# Altium schematic sheet MonRaF_Pi.SchDoc
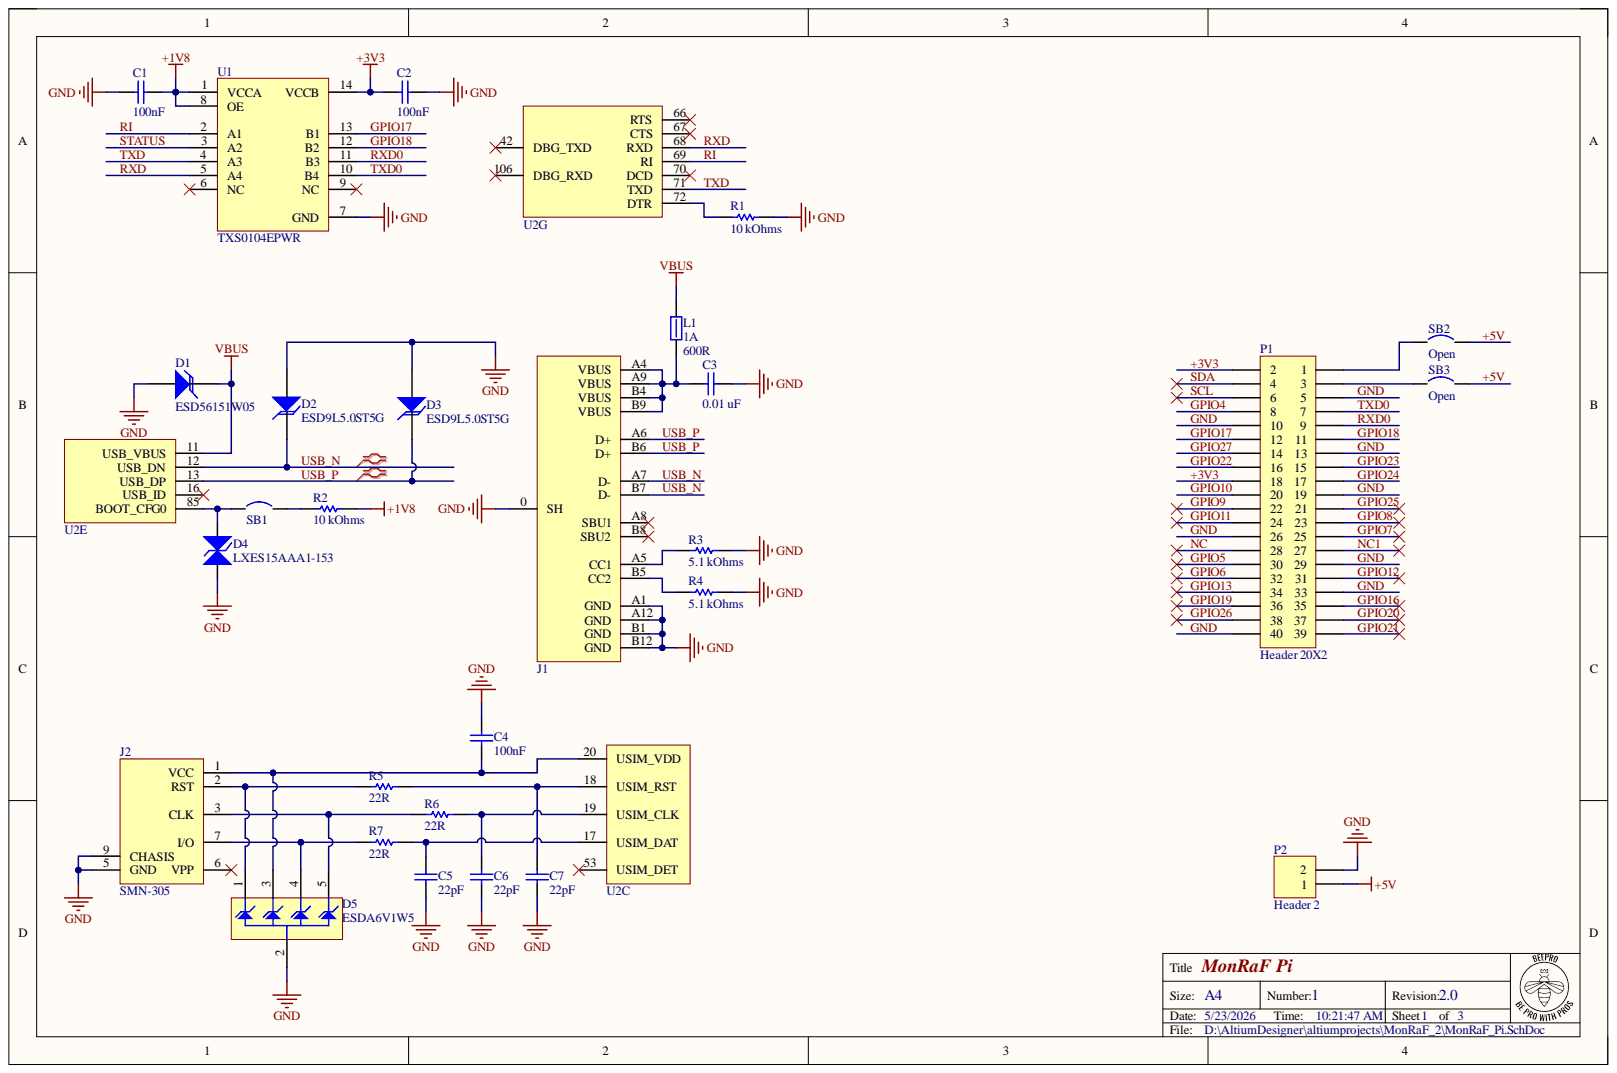
[diagram p0: MonRaF_Pi.SchDoc]

## Parts
C1  Capacitance: 100nF  [0402N]  (hidden comment: 04026D104KAT2A)
C2  Capacitance: 100nF  [0402N]  (hidden comment: 04026D104KAT2A)
C3  Capacitance: 0.01 uF  [0402N]  (hidden comment: CGA2B3X7S2A103K050BB)
C4  Capacitance: 100nF  [0402N]  (hidden comment: 04026D104KAT2A)
C5  Capacitance: 22pF  [0402N]  (hidden comment: 885012005009)
C6  Capacitance: 22pF  [0402N]  (hidden comment: 885012005009)
C7  Capacitance: 22pF  [0402N]  (hidden comment: 885012005009)
D1  ESD56151W05  [SOD-323]
D2  ESD9L5.0ST5G  [SOD923-2N]
D3  ESD9L5.0ST5G  [SOD923-2N]
D4  LXES15AAA1-153  [DFN90P100X45-2N]
D5  ESDA6V1W5  [SOT323-5N]
J1  USB-TYPE-C-018  [USB_C]  (value not drawn)
J2  SMN-305  [NanoSIM_1]
L1  Impedance: 600R  Max Current Rating: 1A  [0603N]  (hidden comment: MPZ1608S601ATD25)
P1  Header 20X2  [HDR2X20]
P2  Header 2  [HDR1X2]
R1  Resistance: 10 kOhms  [0402N]  (hidden comment: CRCW040210K0FKED)
R2  Resistance: 10 kOhms  [0402N]  (hidden comment: CRCW040210K0FKED)
R3  Resistance: 5.1 kOhms  [0402N]  (hidden comment: CRCW04025K10JNED)
R4  Resistance: 5.1 kOhms  [0402N]  (hidden comment: CRCW04025K10JNED)
R5  value 22R  [0402N]  (hidden comment: ERJ-2RKF22R0X)
R6  value 22R  [0402N]  (hidden comment: ERJ-2RKF22R0X)
R7  value 22R  [0402N]  (hidden comment: ERJ-2RKF22R0X)
SB1  Open  [SB_0603]  (value not drawn)
SB2  Open  [SB_0603]
SB3  Open  [SB_0603]
U1  TXS0104EPWR  [TSSOP-14N]
U2  SIM7600G-H  [LCC+LGA-119N]  (value not drawn)  (parts C,E,G)

## Nets
+1V8: C1.1 R2.2 U1.1(VCCA) U1.8(OE)
VBUS: D1.1 L1.2 U2.11(USB_VBUS)
+3V3: C2.2 P1.2 P1.18 U1.14(VCCB)
+5V: P2.1 SB2.2 SB3.2
GND: C1.2 C2.1 C3.2 C4.2 C5.1 C6.1 C7.1 D1.2 D2.2 D3.2 D4.2 D5.2 J1.0(SH) J1.A1(GND) J1.A12(GND) J1.B1(GND) J1.B12(GND) J2.5(GND) J2.9(CHASIS) P1.5 P1.10 P1.13 P1.19 P1.26 P1.29 P1.33 P1.40 P2.2 R1.2 R3.2 R4.2 U1.7(GND)
GPIO4: P1.8
GPIO5: P1.30
GPIO6: P1.32
GPIO7: P1.25
GPIO8: P1.23
GPIO9: P1.22
GPIO10: P1.20
GPIO11: P1.24
GPIO12: P1.31
GPIO13: P1.34
GPIO16: P1.35
GPIO17: P1.12 U1.13(B1)
GPIO18: P1.11 U1.12(B2)
GPIO19: P1.36
GPIO20: P1.37
GPIO21: P1.39
GPIO22: P1.16
GPIO23: P1.15
GPIO24: P1.17
GPIO25: P1.21
GPIO26: P1.38
GPIO27: P1.14
NC: P1.28
NC1: P1.27
RI: U1.2(A1) U2.69(RI)
RXD: U1.5(A4) U2.68(RXD)
RXD0: P1.9 U1.11(B3)
SCL: P1.6
SDA: P1.4
STATUS: U1.3(A2)
TXD: U1.4(A3) U2.71(TXD)
TXD0: P1.7 U1.10(B4)
USB_N: D2.1 J1.A7(D-) J1.B7(D-) U2.12(USB_DN)
USB_P: D3.1 J1.A6(D+) J1.B6(D+) U2.13(USB_DP)
NetC3_1: C3.1 J1.A4(VBUS) J1.A9(VBUS) J1.B4(VBUS) J1.B9(VBUS) L1.1
NetC4_1: C4.1 D5.3 J2.1(VCC) U2.20(USIM_VDD)
NetC5_2: C5.2 R7.2 U2.17(USIM_DAT)
NetC6_2: C6.2 R6.2 U2.19(USIM_CLK)
NetC7_2: C7.2 R5.2 U2.18(USIM_RST)
NetD4_1: D4.1 SB1.1 U2.85(BOOT_CFG0)
NetD5_1: D5.1 J2.2(RST) R5.1
NetD5_4: D5.4 J2.7(I/O) R7.1
NetD5_5: D5.5 J2.3(CLK) R6.1
NetJ1_A5: J1.A5(CC1) R3.1
NetJ1_B5: J1.B5(CC2) R4.1
NetP1_1: P1.1 SB2.1
NetP1_3: P1.3 SB3.1
NetR1_1: R1.1 U2.72(DTR)
NetR2_1: R2.1 SB1.2

Not connected: J1 A8(SBU1) B8(SBU2); J2 6(VPP); U1 6(NC) 9(NC); U2 16(USB_ID) 42(DBG_TXD) 53(USIM_DET) 66(RTS) 67(CTS) 70(DCD) 106(DBG_RXD)

## Library data of the parts
C1 C2 C4 = 04026D104KAT2A: AVX   04026D104KAT2A   Multilayer Ceramic Capacitor, 0.1 F,  10%, X5R, 6.3 V, 0402 [1005 Metric]; Manufacturer: Kyocera AVX; Voltage: 6.3V; Case Code (Imperial): 0402; Case Code (Metric): 1005; Case/Package: 0402; Component Kind: Standard; ComponentLink1Description: https://datasheet.ciiva.com/11787/0900766b80def1a5-11787108.pdf?src-supplier=RSComponents; ComponentLink1URL: https://datasheet.ciiva.com/11787/0900766b80def1a5-11787108.pdf?src-supplier=RSComponents; ComponentLink2Description: https://datasheet.ciiva.com/34303/2009885-34303800.pdf?src-supplier=Jameco; ComponentLink2URL: https://datasheet.ciiva.com/34303/2009885-34303800.pdf?src-supplier=Jameco; ComponentLink3Description: https://datasheet.ciiva.com/1123/1666675-1123895.pdf?src-supplier=Element14; ComponentLink3URL: https://datasheet.ciiva.com/1123/1666675-1123895.pdf?src-supplier=Element14; ComponentLink4Description: https://datasheet.ciiva.com/7709/1666675-7709559.pdf?src-supplier=Element14; ComponentLink4URL: https://datasheet.ciiva.com/7709/1666675-7709559.pdf?src-supplier=Element14; ComponentLink5Description: https://datasheet.ciiva.com/17488/cx5r-17488599.pdf?src-supplier=Avnet+Europe; ComponentLink5URL: https://datasheet.ciiva.com/17488/cx5r-17488599.pdf?src-supplier=Avnet+Europe; ComponentLink6Description: https://datasheet.ciiva.com/1123/1666675-1123896.pdf?src-supplier=Element14; ComponentLink6URL: https://datasheet.ciiva.com/1123/1666675-1123896.pdf?src-supplier=Element14; ComponentLink7Description: https://datasheet.ciiva.com/11499/cx5r-11499578.pdf?src-supplier=Avnet; ComponentLink7URL: https://datasheet.ciiva.com/11499/cx5r-11499578.pdf?src-supplier=Avnet; ComponentLink8Description: https://datasheet.ciiva.com/210/cx5r-210347.pdf?src-supplier=Digi-Key; ComponentLink8URL: https://datasheet.ciiva.com/210/cx5r-210347.pdf?src-supplier=Digi-Key; ComponentLink9Description: https://datasheet.ciiva.com/14886/cx5r-14886812.pdf?src-supplier=Digi-Key; ComponentLink9URL: https://datasheet.ciiva.com/14886/cx5r-14886812.pdf?src-supplier=Digi-Key; ComponentLink10Description: https://datasheet.ciiva.com/2987/cx5r-2987693.pdf?src-supplier=Avnet; ComponentLink10URL: https://datasheet.ciiva.com/2987/cx5r-2987693.pdf?src-supplier=Avnet; Contact Plating: Tin; Depth: 0.5mm; Dielectric: X5R; Dielectric Material: Ceramic; Height: 0.56mm; Lead Free: Lead Free; Length: 1mm; Library Name: Capacitor_Ceramic.IntLib; Manufacturer Part Number: 04026D104KAT2A; Max Operating Temperature: 85°C; Min Operating Temperature: -55°C; Mount: Surface Mount; Package Quantity: 1; Packaging: Tape and Reel; Pricing 1: 1 = 0.10000, 10 = 0.04100, 50 = 0.04100, 100 = 0.01900, 1000 = 0.01000, 10000 = 0.00700 (USD); Radiation Hardening: No; REACH SVHC: No SVHC; RoHS: Yes; Stock 1: 118561; Supplier 1: Mouser; Supplier Part Number 1: 581-04026D104KAT2A; Termination: SMD/SMT; Thickness: 0.022inch; Tolerance: 10%; Voltage Rating: 6.3V; Voltage Rating (DC): 6.3V; Width: 0.02inch
C3 = CGA2B3X7S2A103K050BB: Multilayer Ceramic Capacitors MLCC - SMD/SMT 0402 0.01uF 100volts X7S +/-10%; Brand: TDK; Capacitance - nF: 10 nF; Capacitance - pF: 10000 pF; Tolerance: 10 %; Type: Automotive Mid-Voltage Multilayer Ceramic Chip Capacitor; Voltage Rating DC: 100 VDC; Case Code - in: 0402; Case Code - mm: 1005; Category: Multilayer Ceramic Capacitors MLCC - SMD/SMT; Component Kind: Standard; ComponentLink1Description: http://www.mouser.com/ds/2/400/lcc_automotive_midvoltage_en-355485.pdf; ComponentLink1URL: http://www.mouser.com/ds/2/400/lcc_automotive_midvoltage_en-355485.pdf; Dielectric: X7S; Height: 0.5 mm; Length: 1 mm; Library Name: Capacitor_Ceramic.IntLib; Manufacturer: TDK; Manufacturer Part Number 1: CGA2B3X7S2A103K050BB; Maximum Operating Temperature: + 125 C; Minimum Operating Temperature: - 55 C; Minimum Order Quantity: 1; Package / Case: 0402 (1005 metric); Packaging: Reel; Pricing 1: 1=0.100, 10=0.072, 100=0.027, 500=0.023, 1000=0.018, 2500=0.015, 10000=0.012, 20000=0.011, 50000=0.010 (USD); Product: Automotive MLCCs; Reeling: True; RoHS: RoHS Compliant; RoHS - Mouser: Y; Series: CGA; Standard Pack Qty: 10000; Stock 1: 8256; Supplier 1: Mouser; Supplier Part Number 1: 810-CGA2B3X7S2A103K; Termination Style: SMD/SMT; Width: 0.5 mm
C5-C7 = 885012005009: WURTH ELEKTRONIK - 885012005009 - SMD Multilayer Ceramic Capacitor, 22 pF, 10 V, 0402 [1005 Metric], ± 5%, C0G / NP0; Manufacturer: Wurth Electronics; Voltage Rating: 10V; Case Code (Imperial): 0402; Case Code (Metric): 1005; Case/Package: 0402; Category: Ceramic Capacitors; Component Kind: Standard; ComponentLink1Description: http://www.farnell.com/datasheets/2031615.pdf?src-supplier=Farnell; ComponentLink1URL: http://www.farnell.com/datasheets/2031615.pdf?src-supplier=Farnell; ComponentLink2Description: https://katalog.we-online.de/pbs/datasheet/885012005009.pdf?src-supplier=Digi-Key; ComponentLink2URL: https://katalog.we-online.de/pbs/datasheet/885012005009.pdf?src-supplier=Digi-Key; ComponentLink3Description: http://www.farnell.com/datasheets/2031615.pdf?src-supplier=Element14; ComponentLink3URL: http://www.farnell.com/datasheets/2031615.pdf?src-supplier=Element14; ComponentLink4Description: http://www.farnell.com/datasheets/2031615.pdf?src-supplier=Newark; ComponentLink4URL: http://www.farnell.com/datasheets/2031615.pdf?src-supplier=Newark; Length: 0.039inch; Library Name: Capacitor_Ceramic.IntLib; Manufacturer Part Number: 885012005009; Max Operating Temperature: 125°C; Min Operating Temperature: -55°C; Mount: Surface Mount; Packaging: Tape and Reel; RoHS: Yes; Stock 1: 10822; Supplier 1: Mouser; Supplier Part Number 1: 710-885012005009; Thickness: 0.022inch; Tolerance: 5%; Width: 0.02inch
D1 = ESD56151W05-2/TR: 145A@8/20us 7.5V 2.4kW@8/20us 6.3V Bidirectional 5V SOD-323 TVS Diodes ROHS; Component Kind: Standard; Library Name: Diodes.IntLib
D2 D3 = ESD9L5.0ST5G: TVS Diode, ESD9L, Unidirectional, 5 V, 9.8 V, SOD-923, 2 Pins; Manufacturer: onsemi; Manufacturer Lifecycle Status: ACTIVE; Breakdown Voltage: 5.4V; Clamping Voltage: 9.8V; Element Configuration: Single; ESD Protection: Yes; Forward Voltage: 1V; Leakage Current: 1µA; Max Junction Temperature (Tj): 150°C; Max Power Dissipation: 150mW; Max Surge Current: 1A; Number of Channels: 1; Operating Supply Voltage: 5V; Power Line Protection: No; Reverse Breakdown Voltage: 5.8V; Test Current: 1mA; Value: 500fF; Case/Package: SOD-923-2; Category: TVS Diodes; China RoHS: Compliant; Component Kind: Standard; ComponentLink1Description: https://datasheet.octopart.com:443/ESD9L5.0ST5G-onsemi-datasheet-175423448.pdf?src-supplier=IHS; ComponentLink1URL: https://datasheet.octopart.com:443/ESD9L5.0ST5G-onsemi-datasheet-175423448.pdf?src-supplier=IHS; ComponentLink2Description: https://datasheet.octopart.com:443/ESD9L5.0ST5G-ON-Semiconductor-datasheet-127822405.pdf?src-supplier=Master+Electronics; ComponentLink2URL: https://datasheet.octopart.com:443/ESD9L5.0ST5G-ON-Semiconductor-datasheet-127822405.pdf?src-supplier=Master+Electronics; ComponentLink3Description: https://datasheet.octopart.com:443/ESD9L5.0ST5G-onsemi-datasheet-189128585.pdf?src-supplier=Master+Electronics; ComponentLink3URL: https://datasheet.octopart.com:443/ESD9L5.0ST5G-onsemi-datasheet-189128585.pdf?src-supplier=Master+Electronics; ComponentLink4Description: https://sigma.octopart.com:443/141945501/technical_drawing/ON-Semiconductor-ESD9L5.0ST5G.pdf?src-supplier=Upverter; ComponentLink4URL: https://sigma.octopart.com:443/141945501/technical_drawing/ON-Semiconductor-ESD9L5.0ST5G.pdf?src-supplier=Upverter; ComponentLink5Description: https://datasheet.octopart.com:443/ESD9L5.0ST5G-ON-Semiconductor-datasheet-12551494.pdf?src-supplier=RS+(Formerly+Allied+Electronics); ComponentLink5URL: https://datasheet.octopart.com:443/ESD9L5.0ST5G-ON-Semiconductor-datasheet-12551494.pdf?src-supplier=RS+(Formerly+Allied+Electronics); ComponentLink6Description: https://datasheet.octopart.com:443/ESD9L5.0ST5G-ON-Semiconductor-datasheet-81469654.pdf?src-supplier=element14+APAC; ComponentLink6URL: https://datasheet.octopart.com:443/ESD9L5.0ST5G-ON-Semiconductor-datasheet-81469654.pdf?src-supplier=element14+APAC; ComponentLink7Description: https://datasheet.octopart.com:443/ESD9L5.0ST5G-ON-Semiconductor-datasheet-8501466.pdf?src-supplier=element14+APAC; ComponentLink7URL: https://datasheet.octopart.com:443/ESD9L5.0ST5G-ON-Semiconductor-datasheet-8501466.pdf?src-supplier=element14+APAC; ComponentLink8Description: https://datasheet.octopart.com:443/ESD9L5.0ST5G-ON-Semiconductor-datasheet-7624372.pdf?src-supplier=element14+APAC; ComponentLink8URL: https://datasheet.octopart.com:443/ESD9L5.0ST5G-ON-Semiconductor-datasheet-7624372.pdf?src-supplier=element14+APAC; ComponentLink9Description: https://datasheet.octopart.com:443/ESD9L5.0ST5G-ON-Semiconductor-datasheet-11972919.pdf?src-supplier=Arrow+Electronics; ComponentLink9URL: https://datasheet.octopart.com:443/ESD9L5.0ST5G-ON-Semiconductor-datasheet-11972919.pdf?src-supplier=Arrow+Electronics; ComponentLink10Description: https://datasheet.octopart.com:443/ESD9L5.0ST5G-ON-Semiconductor-datasheet-14049047.pdf?src-supplier=iiiC; ComponentLink10URL: https://datasheet.octopart.com:443/ESD9L5.0ST5G-ON-Semiconductor-datasheet-14049047.pdf?src-supplier=iiiC; ComponentLink11Description: https://datasheet.octopart.com:443/ESD9L5.0ST5G-ON-Semiconductor-datasheet-631477.pdf?src-supplier=Future+Electronics; ComponentLink11URL: https://datasheet.octopart.com:443/ESD9L5.0ST5G-ON-Semiconductor-datasheet-631477.pdf?src-supplier=Future+Electronics; ComponentLink12Description: https://datasheet.octopart.com:443/ESD9L5.0ST5G-ON-Semiconductor-datasheet-163291.pdf?src-supplier=Future+Electronics; ComponentLink12URL: https://datasheet.octopart.com:443/ESD9L5.0ST5G-ON-Semiconductor-datasheet-163291.pdf?src-supplier=Future+Electronics; ComponentLink13Description: https://datasheet.octopart.com:443/ESD9L5.0ST5G-onsemi-datasheet-17741101.pdf?src-supplier=Newchip+Electronics+Limited; ComponentLink13URL: https://datasheet.octopart.com:443/ESD9L5.0ST5G-onsemi-datasheet-17741101.pdf?src-supplier=Newchip+Electronics+Limited; ComponentLink14Description: http://sigma.octopart.com:80/15540786/rohs_certificate/ON-Semiconductor-ESD9L5.0ST5G.pdf?src-supplier=Compliance+Statements; ComponentLink14URL: http://sigma.octopart.com:80/15540786/rohs_certificate/ON-Semiconductor-ESD9L5.0ST5G.pdf?src-supplier=Compliance+Statements; ComponentLink15Description: http://sigma.octopart.com:80/15676172/materials_sheet/ON-Semiconductor-ESD9L5.0ST5G.csv?src-supplier=Compliance+Statements; ComponentLink15URL: http://sigma.octopart.com:80/15676172/materials_sheet/ON-Semiconductor-ESD9L5.0ST5G.csv?src-supplier=Compliance+Statements; ComponentLink16Description: http://sigma.octopart.com:80/150607603/rohs_statement/ON-Semiconductor-ESD9L5.0ST5G.pdf?src-supplier=Compliance+Statements; ComponentLink16URL: http://sigma.octopart.com:80/150607603/rohs_statement/ON-Semiconductor-ESD9L5.0ST5G.pdf?src-supplier=Compliance+Statements; ComponentLink17Description: http://sigma.octopart.com:80/reach_statement/ON-Semiconductor-company-79.pdf?src-supplier=Compliance+Statements; ComponentLink17URL: http://sigma.octopart.com:80/reach_statement/ON-Semiconductor-company-79.pdf?src-supplier=Compliance+Statements; ComponentLink18Description: http://sigma.octopart.com:80/reach_statement/Fairchild-Semiconductor-company-9.pdf?src-supplier=Compliance+Statements; ComponentLink18URL: http://sigma.octopart.com:80/reach_statement/Fairchild-Semiconductor-company-9.pdf?src-supplier=Compliance+Statements; ComponentLink19Description: http://sigma.octopart.com:80/rohs_statement/Fairchild-Semiconductor-company-10.pdf?src-supplier=Compliance+Statements; ComponentLink19URL: http://sigma.octopart.com:80/rohs_statement/Fairchild-Semiconductor-company-10.pdf?src-supplier=Compliance+Statements; ComponentLink20Description: http://sigma.octopart.com:80/rohs_statement/Fairchild-Semiconductor-company-11.pdf?src-supplier=Compliance+Statements; ComponentLink20URL: http://sigma.octopart.com:80/rohs_statement/Fairchild-Semiconductor-company-11.pdf?src-supplier=Compliance+Statements; ComponentLink21Description: https://octopart.com:443/opatz8j6/c1?t=7w0XnnSi4sM1YneuYEfMx0W7BS4gNqlQ77EKJzQ4fx8gzzTvMSaB5amYKeL1PGgCyXOe3F_2j9WID8EG-1C9UfPbEnIiubeoRzn49F_sjCuv1QSrjs-6Mb6mes9_XSk7q39_1np2StN2BwMZScs9th6cTzXOMSTaDwt5Ixccs6MPPQmJ4j1eu5z3GjEn1sKF9915AFcPnOvoMGS6zz3DOOdiVl2DvcsB_5Uf3KMhcld1n-e8dYHuqg8-5WxukLsy_zLwh_Pw4ogxsOTzxio3YcsvvdP8Ez9Wsp3tKoSK-axvukdl-Emb4RCN3eJsb1JorMRvVqlLpWdIL7ICUSKOluejx7FJjDK6AKVXoHXA&src-supplier=Compliance+Statements; ComponentLink21URL: https://octopart.com:443/opatz8j6/c1?t=7w0XnnSi4sM1YneuYEfMx0W7BS4gNqlQ77EKJzQ4fx8gzzTvMSaB5amYKeL1PGgCyXOe3F_2j9WID8EG-1C9UfPbEnIiubeoRzn49F_sjCuv1QSrjs-6Mb6mes9_XSk7q39_1np2StN2BwMZScs9th6cTzXOMSTaDwt5Ixccs6MPPQmJ4j1eu5z3GjEn1sKF9915AFcPnOvoMGS6zz3DOOdiVl2DvcsB_5Uf3KMhcld1n-e8dYHuqg8-5WxukLsy_zLwh_Pw4ogxsOTzxio3YcsvvdP8Ez9Wsp3tKoSK-axvukdl-Emb4RCN3eJsb1JorMRvVqlLpWdIL7ICUSKOluejx7FJjDK6AKVXoHXA&src-supplier=Compliance+Statements; ComponentLink22Description: https://octopart.com:443/opatz8j6/c1?t=QBJoTZ_uZaPSDITLnPNjk40_sRpE3jp2kMEGYsoZafPiQlM6KuF7CQca4VlrCw6RwKnh_V1CC7FYpbmVgKn8Li8wdqZv0OIIjwpig92xSLqaF6UwoX01cHZf_6iQ28uun9v5O9wPyD9emC3yGGZ8Rc8A6wAkqPaRgAPAvHn7BZhS7luu5qVeRLwMGog07YPPBMR3zps8PVr1gZMv5mb_RSRv9U-5kzglsnLbcPA6V2aZ_c35O8Mg_yDFNfBVwOCoaL_LzzSL3g8UeUyzXm-yJaM5s-dicLueh7kna4O_6J7ale_qOWkbOylfYGClMo9ZuZsQ1o3Hmp0h6FKr6kXzE3u62JBxMwLYRP7vYQ6aTg&src-supplier=Compliance+Statements; ComponentLink22URL: https://octopart.com:443/opatz8j6/c1?t=QBJoTZ_uZaPSDITLnPNjk40_sRpE3jp2kMEGYsoZafPiQlM6KuF7CQca4VlrCw6RwKnh_V1CC7FYpbmVgKn8Li8wdqZv0OIIjwpig92xSLqaF6UwoX01cHZf_6iQ28uun9v5O9wPyD9emC3yGGZ8Rc8A6wAkqPaRgAPAvHn7BZhS7luu5qVeRLwMGog07YPPBMR3zps8PVr1gZMv5mb_RSRv9U-5kzglsnLbcPA6V2aZ_c35O8Mg_yDFNfBVwOCoaL_LzzSL3g8UeUyzXm-yJaM5s-dicLueh7kna4O_6J7ale_qOWkbOylfYGClMo9ZuZsQ1o3Hmp0h6FKr6kXzE3u62JBxMwLYRP7vYQ6aTg&src-supplier=Compliance+Statements; Composition: Zener; Contact Plating: Tin; Depth: 600µm; Direction: Unidirectional; Halogen Free: Halogen Free; Height: 400µm; Lead Free: Lead Free; Length: 800µm; Library Name: Diodes.IntLib; Lifecycle Status: Production; Manufacturer Part Number: ESD9L5.0ST5G; Max Breakdown Voltage: 5.4V; Max Operating Temperature: 125°C; Max Reverse Leakage Current: 1µA; Min Breakdown Voltage: 5.4V; Min Operating Temperature: -55°C; Number of Elements: 1; Number of Lines: 1; Number of Terminals: 2; Number of Unidirectional Channels: 1; Packaging: Tape and Reel; Peak Pulse Current: 1A; Peak Pulse Power: 150mW; Peak Reverse Current: 1µA; Pins: 2; Polarity: Unidirectional; Power Dissipation: 150mW; Pricing: 1=0.22, 10=0.151, 50=0.151, 100=0.067, 1000=0.063, 10000=0.046 (USD); Radiation Hardening: No; REACH SVHC: Yes; Reverse Standoff Voltage: 5V; RoHS: Compliant; Schedule B: 8536300000, 8536300000|8536300000|8536300000|8536300000|8536300000|8536300000|8536300000|8536300000|8536300000|8536300000|8536300000|8536300000|8536300000|8536300000|8536300000|8536300000|8536300000|8536300000|8536300000|8536300000|8536300000|8536300000|8536300000|85; Stock: 117092; Supplier: Mouser; Supplier Part Number: 863-ESD9L5.0ST5G; Termination: SMD/SMT; Width: 650µm; Working Voltage: 5V
D4 = LXES15AAA1-153: 0402 ESD and Surge Protection (TVS/ESD) ROHS; Manufacturer: LEIDITECH; Component Kind: Standard; Library Name: Diodes.IntLib; Manufacturer Part Number: LXES15AAA1-153; Pricing 1: 10=0.0353, 100=0.0289, 300=0.0257, 1000=0.0233, 5000=0.0204, 10000=0.0194 (USD); RoHS: No; Stock 1: 16704; Supplier 1: LCSC; Supplier Part Number 1: C5210978
D5 = ESDA6V1W5: Trans Voltage Suppressor Diode, 150W, 3V V(RWM), Unidirectional, 4 Element, Silicon; Manufacturer: STMicroelectronics; Max Breakdown Voltage: 7.2V; Min Breakdown Voltage: 6.1V; Number of Elements: 4; Package Body Material: Plastic; Qualification Status: Not Qualified; Time @ Peak Reflow Temperature-Max (s): 30s; Category: TVS Diodes; Component Kind: Standard; ComponentLink1Description: https://datasheet.ciiva.com:443/pdfs/VipMasterIC/IC/SGST/SGSTS34744/SGSTS34744-1.pdf?src-supplier=IHS+Markit; ComponentLink1URL: https://datasheet.ciiva.com:443/pdfs/VipMasterIC/IC/SGST/SGSTS34744/SGSTS34744-1.pdf?src-supplier=IHS+Markit; ComponentLink2Description: https://datasheet.ciiva.com/15143/getdatasheetpartid-134557-15143650.pdf?src-supplier=Verical; ComponentLink2URL: https://datasheet.ciiva.com/15143/getdatasheetpartid-134557-15143650.pdf?src-supplier=Verical; ComponentLink3Description: https://datasheet.ciiva.com/22695/1671027-22695673.pdf?src-supplier=Element14; ComponentLink3URL: https://datasheet.ciiva.com/22695/1671027-22695673.pdf?src-supplier=Element14; ComponentLink4Description: https://datasheet.ciiva.com/338/cd00064033-338380.pdf?src-supplier=Digi-Key; ComponentLink4URL: https://datasheet.ciiva.com/338/cd00064033-338380.pdf?src-supplier=Digi-Key; ComponentLink5Description: https://datasheet.ciiva.com/338/cd00064033-338379.pdf?src-supplier=Digi-Key; ComponentLink5URL: https://datasheet.ciiva.com/338/cd00064033-338379.pdf?src-supplier=Digi-Key; ComponentLink6Description: https://datasheet.ciiva.com/338/cd00064033-338381.pdf?src-supplier=Digi-Key; ComponentLink6URL: https://datasheet.ciiva.com/338/cd00064033-338381.pdf?src-supplier=Digi-Key; ComponentLink7Description: https://datasheet.ciiva.com/1276/1671027-1276908.pdf?src-supplier=Element14; ComponentLink7URL: https://datasheet.ciiva.com/1276/1671027-1276908.pdf?src-supplier=Element14; ComponentLink8Description: https://datasheet.ciiva.com/41443/001184222-da-01-en-transil-array-da-esda6v1w5-sot-323-5-stm-41443642.pdf?src-supplier=Conrad; ComponentLink8URL: https://datasheet.ciiva.com/41443/001184222-da-01-en-transil-array-da-esda6v1w5-sot-323-5-stm-41443642.pdf?src-supplier=Conrad; Diode Element Material: SILICON; Diode Type: TRANS VOLTAGE SUPPRESSOR; JESD-30 Code: R-PDSO-G5; JESD-609 Code: e3; Library Name: Diodes.IntLib; Manufacturer Part Number: ESDA6V1W5; Max Operating Temperature: 125°C; Moisture Sensitivity Level: 1; Non-rep Peak Rev Power Dis-Max: 150W; Package Shape: Rectangular; Package Style: SMALL OUTLINEMeter; Peak Reflow Temperature (Cel): 260°C; Pins: 5; Polarity: Unidirectional; Pricing 1: 5=0.0935, 50=0.077, 150=0.0687, 500=0.0625, 3000=0.0576, 6000=0.0551 (USD); Reach SVHC Compliant: Yes; RoHS: Yes; RoHS Compliant: Yes; Stock 1: 6275; Supplier 1: LCSC; Supplier Part Number 1: C48677; Surface Mount: Yes; Technology: AVALANCHE; Terminal Finish: Tin; Terminal Form: Gull Wing; Terminal Position: DUAL
J1 = USB-TYPE-C-018: 3A 1 Horizontal attachment 16P Female -25℃~+85℃ Type-C SMD USB Connectors ROHS; Component Kind: Standard; Library Name: Connectors.IntLib
J2 = SMN-305: Flip type 1.4mm Card Slot -25℃~+85℃ Nano SIM card SMD SIM Card Connectors ROHS; Manufacturer: Xunpu; Component Kind: Standard; Library Name: Connectors.IntLib; Manufacturer Part Number: SMN-305; Pricing 1: 5=0.1802, 50=0.1426, 150=0.1243, 1500=0.1079, 3000=0.1034, 4500=0.1007 (USD); RoHS: No; Stock 1: 58876; Supplier 1: LCSC; Supplier Part Number 1: C266890
L1 = MPZ1608S601ATD25: Ferrite Beads 0603 600ohms 1000mA Power Line AEC-Q200; Manufacturer: TDK; DC Resistance (DCR): 150mR; Number of Lines: 1; Test Frequency: 100MHz; Tolerance: 25%; Case Code (Imperial): 0603; Case Code (Metric): 1608; Case/Package: 0603; Component Kind: Standard; Height: 0.037inch; Lead Free: Lead Free; Length: 0.063inch; Library Name: Inductors.IntLib; Manufacturer Part Number: MPZ1608S601ATD25; Max DC Current: 1A; Max Operating Temperature: 125°C; Min Operating Temperature: -55°C; Mount: Surface Mount; Package Quantity: 4000; Packaging: Tape and Reel; Pricing 1: 1=0.11, 10=0.086, 50=0.086, 100=0.039, 1000=0.028, 10000=0.021 (USD); Ratings: AEC-Q200; Stock 1: 89242; Supplier 1: Mouser; Supplier Part Number 1: 810-MPZ1608S601ATD25; Termination: SMD/SMT; Weight: 7.1E-05oz; Width: 0.031inch
P1 = Header 20X2: Header, 20-Pin, Dual row; Component Kind: Standard; LatestRevisionDate: 17-Jul-2002; LatestRevisionNote: Re-released for DXP Platform.; Library Name: Miscellaneous Connectors.IntLib; Signal Integrity: Connector; Publisher: Altium Limited
P2 = Header 2: Header, 2-Pin; Component Kind: Standard; LatestRevisionDate: 17-Jul-2002; LatestRevisionNote: Re-released for DXP Platform.; Library Name: Miscellaneous Connectors.IntLib; Signal Integrity: Connector; Publisher: Altium Limited
R1 R2 = CRCW040210K0FKED: Thick Film Resistors - SMD 1/16watt 10Kohms 1%; Brand: Vishay; Power Rating: 63 mW; Temperature Coefficient: 100 PPM / K; Voltage Rating: 50 V; Case Code - in: 0402; Case Code - mm: 1005; Category: Thick Film Resistors - SMD; Component Kind: Standard; ComponentLink1Description: http://www.mouser.com/ds/2/427/dcrcwe3-109170.pdf; ComponentLink1URL: http://www.mouser.com/ds/2/427/dcrcwe3-109170.pdf; Features: Metal glaze on high quality ceramic; Height: 0.35 mm; Length: 1 mm; Library Name: Resistor_0402.IntLib; Manufacturer: Vishay; Manufacturer Part Number 1: CRCW040210K0FKED; Minimum Order Quantity: 1; Operating Temperature Range: - 55 C to + 155 C; Package / Case: 0402 (1005 metric); Packaging: Reel; Pricing 1: 1=0.100, 10=0.045, 100=0.026, 1000=0.018, 10000=0.006, 30000=0.005, 50000=0.004, 250000=0.003 (USD); Product: Thick Film Resistors SMD; Reeling: True; RoHS: RoHS Compliant By Exemption; RoHS - Mouser: E; Series: CRCW e3; Standard Pack Qty: 10000; Stock 1: 1255323; Supplier 1: Mouser; Supplier Part Number 1: 71-CRCW0402-10K-E3; Termination Style: SMD/SMT; Tolerance: 1 %; Width: 0.5 mm
R3 R4 = CRCW04025K10JNED: Thick Film Resistors - SMD 1/16watt 5.1Kohms 5%; Brand: Vishay; Power Rating: 63 mW; Qualification: Commercial; Temperature Coefficient: 200 PPM / K; Voltage Rating: 50 V; Case Code - in: 0402; Case Code - mm: 1005; Category: Thick Film Resistors - SMD; Component Kind: Standard; ComponentLink1Description: http://www.mouser.com/ds/2/427/dcrcwe3-109170.pdf; ComponentLink1URL: http://www.mouser.com/ds/2/427/dcrcwe3-109170.pdf; Features: Metal glaze on high quality ceramic; Height: 0.35 mm; Length: 1 mm; Library Name: Resistor_0402.IntLib; Manufacturer: Vishay; Manufacturer Part Number 1: CRCW04025K10JNED; Minimum Order Quantity: 1; Operating Temperature Range: - 55 C to + 155 C; Package / Case: 0402 (1005 metric); Packaging: Reel; Pricing 1: 1=0.100, 10=0.040, 100=0.023, 1000=0.016, 10000=0.004, 50000=0.003 (USD); Product: Thick Film Resistors SMD; Reeling: True; RoHS: RoHS Compliant By Exemption; RoHS - Mouser: E; Series: CRCW e3; Standard Pack Qty: 10000; Stock 1: 30657; Supplier 1: Mouser; Supplier Part Number 1: 71-CRCW0402J-5.1K-E3; Termination Style: SMD/SMT; Tolerance: 5 %; Width: 0.5 mm
R5-R7 = ERJ-2RKF22R0X: Fixed Resistor, Metal Glaze/thick Film, 0.1W, 22ohm, 50V, 1% +/-Tol, 100ppm/Cel, Surface Mount, 0402; Manufacturer: Panasonic; Package Height: 0.35mm; Package Length: 1mm; Package Width: 0.5mm; Power: 100mW; Rated Temperature: 70°C; Reference Standard: AEC-Q200; Temperature Coefficient: 100ppm/°C; Case/Package: 0402; Category: Chip SMD Resistors; Component Kind: Standard; ComponentLink1Description: https://datasheet.ciiva.com:443/pdfs/VipMasterIC/IC/PANA/PANA-S-A0009889626/PANA-S-A0009889626-1.pdf?src-supplier=IHS+Markit; ComponentLink1URL: https://datasheet.ciiva.com:443/pdfs/VipMasterIC/IC/PANA/PANA-S-A0009889626/PANA-S-A0009889626-1.pdf?src-supplier=IHS+Markit; ComponentLink2Description: https://datasheet.ciiva.com/7909/426256-7909542.pdf?src-supplier=Element14; ComponentLink2URL: https://datasheet.ciiva.com/7909/426256-7909542.pdf?src-supplier=Element14; ComponentLink3Description: https://datasheet.ciiva.com/46373/1678782-46373429.pdf?src-supplier=Element14; ComponentLink3URL: https://datasheet.ciiva.com/46373/1678782-46373429.pdf?src-supplier=Element14; ComponentLink4Description: https://datasheet.ciiva.com/22674/1678782-22674412.pdf?src-supplier=Element14; ComponentLink4URL: https://datasheet.ciiva.com/22674/1678782-22674412.pdf?src-supplier=Element14; ComponentLink5Description: https://datasheet.ciiva.com/28546/1678782-28546095.pdf?src-supplier=Element14; ComponentLink5URL: https://datasheet.ciiva.com/28546/1678782-28546095.pdf?src-supplier=Element14; ComponentLink6Description: https://datasheet.ciiva.com/46373/1678782-46373430.pdf?src-supplier=Element14; ComponentLink6URL: https://datasheet.ciiva.com/46373/1678782-46373430.pdf?src-supplier=Element14; ComponentLink7Description: https://datasheet.ciiva.com/16777/70260748-16777851.pdf?src-supplier=Allied+Electronics; ComponentLink7URL: https://datasheet.ciiva.com/16777/70260748-16777851.pdf?src-supplier=Allied+Electronics; ComponentLink8Description: https://datasheet.ciiva.com/9191/aoa0000ce2-9191817.pdf?src-supplier=Avnet; ComponentLink8URL: https://datasheet.ciiva.com/9191/aoa0000ce2-9191817.pdf?src-supplier=Avnet; ComponentLink9Description: https://datasheet.ciiva.com/16426/aoa0000ce2-16426205.pdf?src-supplier=Future+Electronics; ComponentLink9URL: https://datasheet.ciiva.com/16426/aoa0000ce2-16426205.pdf?src-supplier=Future+Electronics; ComponentLink10Description: https://datasheet.ciiva.com/5868/aoa0000ce2-5868120.pdf?src-supplier=Farnell; ComponentLink10URL: https://datasheet.ciiva.com/5868/aoa0000ce2-5868120.pdf?src-supplier=Farnell; ComponentLink11Description: https://datasheet.ciiva.com/43467/1678782-43467158.pdf?src-supplier=Farnell; ComponentLink11URL: https://datasheet.ciiva.com/43467/1678782-43467158.pdf?src-supplier=Farnell; Construction: Rectangular; JESD-609 Code: e3; Library Name: Resistor_0402.IntLib; Manufacturer Part Number: ERJ-2RKF22R0X; Max Operating Temperature: 155°C; Min Operating Temperature: -55°C; Mounting Technology: Surface Mount; Package Shape: Rectangular; Package Style: SMTMeter; Packaging: Tape and Reel; Pins: 2; Pricing: 1=0.1, 10=0.017, 50=0.017, 100=0.008, 1000=0.006, 10000=0.004 (USD); Reach SVHC Compliant: Yes; Resistor Type: FIXED RESISTOR; RoHS: Yes; RoHS Compliant: Yes; Stock: 852771; Supplier: Mouser; Supplier Part Number: 667-ERJ-2RKF22R0X; Technology: METAL GLAZE/THICK FILM; Terminal Finish: Nickel; Termination: Wraparound; Tolerance: 1%; Working Voltage: 50V
SB1-SB3 = Circuit Breaker: Component Kind: Standard; Description: Circuit Breaker; Library Name: Connectors.IntLib; Published: 24-Mar-1999; Revision: July-2002: Re-released for DXP Platform.; Publisher: Altium Limited
U1 = TXS0104EPWR: Interface Circuit, PDSO14; Manufacturer: Texas Instruments; Channels: 1; Max Supply Voltage: 3.6V; Min Supply Voltage: 1.65V; Nominal Supply Voltage: 1.8V; Package Body Material: Plastic; Package Equivalence Code: TSSOP14,.25; Power Supplies: 1.8/3.3,2.5/5V; Qualification Status: Not Qualified; Supply Voltage1-Max: 5.5V; Supply Voltage1-Min: 2.3V; Supply Voltage1-Nom: 3.3V; Case/Package: TSSOP; Category: Voltage Level Shifters; Component Kind: Standard; ComponentLink1Description: https://www.ti.com:443/lit/ds/symlink/txs0104e.pdf?src-supplier=IHS+Markit; ComponentLink1URL: https://www.ti.com:443/lit/ds/symlink/txs0104e.pdf?src-supplier=IHS+Markit; ComponentLink2Description: https://datasheet.ciiva.com/15096/getdatasheetpartid-172988-15096218.pdf?src-supplier=Verical; ComponentLink2URL: https://datasheet.ciiva.com/15096/getdatasheetpartid-172988-15096218.pdf?src-supplier=Verical; ComponentLink3Description: https://datasheet.ciiva.com/1899/txs0104e-1899556.pdf?src-supplier=Digikey; ComponentLink3URL: https://datasheet.ciiva.com/1899/txs0104e-1899556.pdf?src-supplier=Digikey; ComponentLink4Description: https://datasheet.ciiva.com/1899/txs0104e-1899557.pdf?src-supplier=Digikey; ComponentLink4URL: https://datasheet.ciiva.com/1899/txs0104e-1899557.pdf?src-supplier=Digikey; ComponentLink5Description: https://datasheet.ciiva.com/1899/txs0104e-1899558.pdf?src-supplier=Digikey; ComponentLink5URL: https://datasheet.ciiva.com/1899/txs0104e-1899558.pdf?src-supplier=Digikey; ComponentLink6Description: https://datasheet.ciiva.com/14287/txs0104e-14287275.pdf?src-supplier=Avnet; ComponentLink6URL: https://datasheet.ciiva.com/14287/txs0104e-14287275.pdf?src-supplier=Avnet; ComponentLink7Description: https://datasheet.ciiva.com/48702/001073825-da-01-en-ic-4bit-non-inv-txs0104epwr-tssop-14-tid-48702635.pdf?src-supplier=Conrad; ComponentLink7URL: https://datasheet.ciiva.com/48702/001073825-da-01-en-ic-4bit-non-inv-txs0104epwr-tssop-14-tid-48702635.pdf?src-supplier=Conrad; Height - Seated (Max): 1.2mm; Interface IC Type: INTERFACE CIRCUIT; JESD-30 Code: R-PDSO-G14; JESD-609 Code: e4; Length: 5mm; Library Name: Texas_Instrument.IntLib; Manufacturer Part Number: TXS0104EPWR; Max Operating Temperature: 85°C; Min Operating Temperature: -40°C; Moisture Sensitivity Level: 1; Package Shape: Rectangular; Package Style: SMALL OUTLINE, THIN PROFILE, SHRINK PITCHMeter; Peak Reflow Temperature (Cel): 260°C; Pins: 14; Pricing 1: 1=1.06, 10=0.654, 50=0.654, 100=0.523, 1000=0.476, 10000=0.428 (USD); Reach SVHC Compliant: Yes; RoHS: Yes; RoHS Compliant: Yes; Stock 1: 17987; Supplier 1: Mouser; Supplier Part Number 1: 595-TXS0104EPWR; Surface Mount: Yes; Temperature Grade: INDUSTRIAL; Terminal Finish: Nickel; Terminal Form: Gull Wing; Terminal Pitch: 0.65mm; Terminal Position: DUAL; Width: 4.4mm
U2 = SIM7600G-H: Cellular Module, GSM, LTE-FDD, LTE-TDD, WCDMA, 3.4 V to 4.2 V, 30 mm x 30 mm x 2.9 mm; Manufacturer: Simcom; Manufacturer Part Number: SIM7600G-H R2; Category: RF Transceiver Modules and Modems; Component Kind: Standard; Length: 30m; Library Name: SIMCom.IntLib; Pricing: 1=51.98, 10=45.558, 25=43.3328, 100=40.2842 (USD); Stock: 421; Supplier: DigiKey; Supplier Part Number: 3781-SIM7600G-HR2-ND
